# Altium schematic sheet PWR.SchDoc
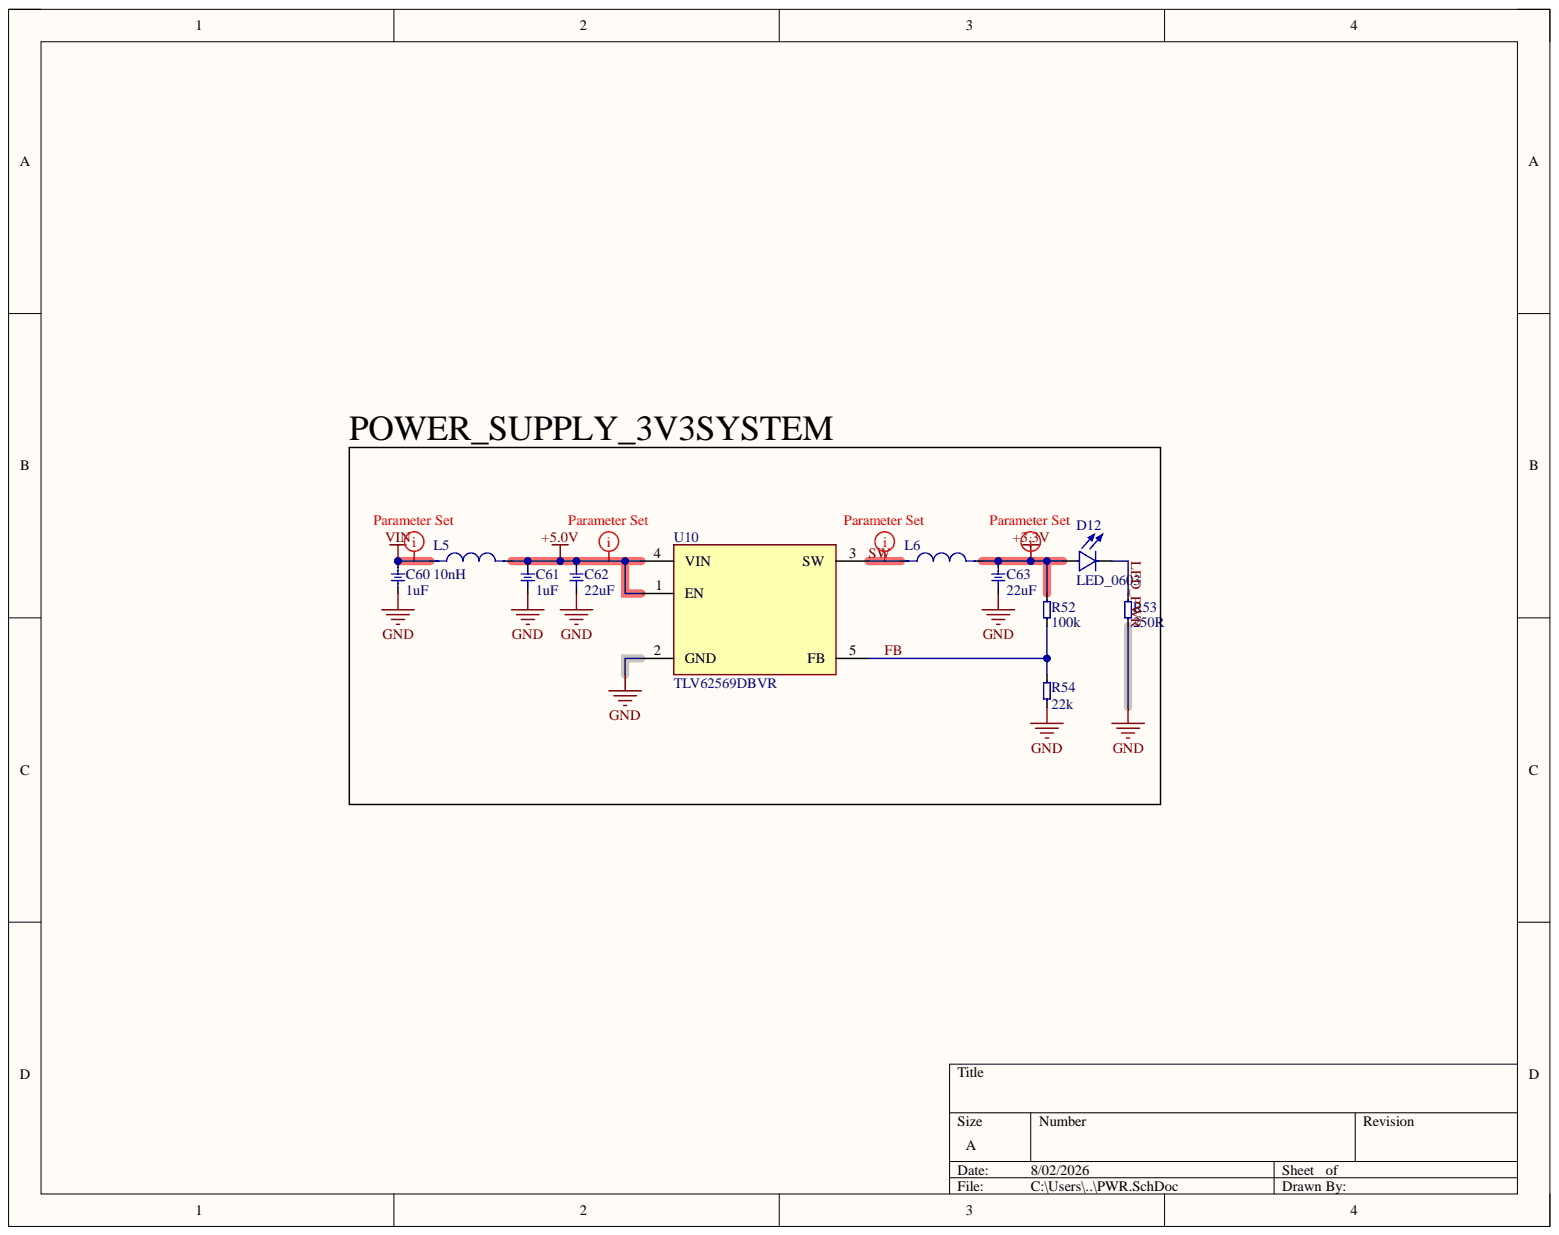
[diagram p0: PWR.SchDoc]

## Parts
C60  1uF  [CAP_0603]
C61  1uF  [CAP_0603]
C62  22uF  [CAP_0805]
C63  22uF  [CAP_0805]
D12  LED_0603  [LED_0603]
L5  10nH  [IND_0603]
L6  1239AS-H-2R2M=P2  [IND_1008]  (value not drawn)
R52  100k  [RES_0402]
R53  250R  [RES_0402]
R54  22k  [RES_0402]
U10  TLV62569DBVR  [SOT95P280X145-5N]

## Nets
+3.3V: C63.2 D12.A L6.2 R52.2
+5.0V: C61.2 C62.2 L5.2 U10.1(EN) U10.4(VIN)
GND: C60.1 C61.1 C62.1 C63.1 R53.1 R54.1 U10.2(GND)
VIN: C60.2 L5.1
FB: R52.1 R54.2 U10.5(FB)
LED_PWR: D12.C R53.2
SW: L6.1 U10.3(SW)

## Library data of the parts
C60 C61 = CAP_0603: Component Kind: Standard; Library Name: RQSchlib.SchLib
C62 C63 = CAP_0805: Component Kind: Standard; Library Name: RQSchlib.SchLib
D12 = LED_0603: LED SMD 0603 Ultra Yellow 593nm 70mcd Water Clear Lens 130 Deg Viewing Angle | Bivar Inc. SM0603UYC; Check_prices: https://www.snapeda.com/parts/SM0603UYC/Bivar/view-part/?ref=eda; Component Kind: Standard; MF: Bivar; MP: SM0603UYC; Package: 0603 Bivar; SnapEDA_Link: https://www.snapeda.com/parts/SM0603UYC/Bivar/view-part/?ref=snap; Library Name: RQSchlib.SchLib
L5 = IND_0603: MF: TDK; MP: MLG1005S2N0BT000; Check_prices: https://www.snapeda.com/parts/MLG1005S2N0BT000/TDK/view-part/?ref=eda; Component Kind: Standard; Digi-Key_Part_Number: 445-16277-2-ND; Package: 1005-2 TDK; Purchase-URL: https://pricing.snapeda.com/search?q=MLG1005S2N0BT000&ref=eda; SnapEDA_Link: https://www.snapeda.com/parts/MLG1005S2N0BT000/TDK/view-part/?ref=snap; Library Name: RQSchlib.SchLib
L6 = IND_1008: 2A 2.2uH ±20% 2.2A 1008 Power Inductors ROHS; MF: TDK; MP: MLG1005S2N0BT000; Check_prices: https://www.snapeda.com/parts/MLG1005S2N0BT000/TDK/view-part/?ref=eda; Component Kind: Standard; Digi-Key_Part_Number: 445-16277-2-ND; Package: 1005-2 TDK; Purchase-URL: https://pricing.snapeda.com/search?q=MLG1005S2N0BT000&ref=eda; SnapEDA_Link: https://www.snapeda.com/parts/MLG1005S2N0BT000/TDK/view-part/?ref=snap; Library Name: RQSchlib.SchLib
R52-R54 = RES_0402: Component Kind: Standard; Library Name: RQSchlib.SchLib
U10 = TLV62569DBVR: 2.5V-5.5V input, 2-A high efficiency step-down buck converter in SOT23 and SOT563 package; MF: Texas Instruments; Check_prices: https://www.snapeda.com/parts/TLV62569DBVR/Texas+Instruments/view-part/?ref=eda; Component Kind: Standard; MP: TLV62569DBVR; Package: SOT-23-5 Texas Instruments; SnapEDA_Link: https://www.snapeda.com/parts/TLV62569DBVR/Texas+Instruments/view-part/?ref=snap; Library Name: RQSchlib.SchLib
